# Altium schematic sheet INTEGRATION_SHEET.SchDoc
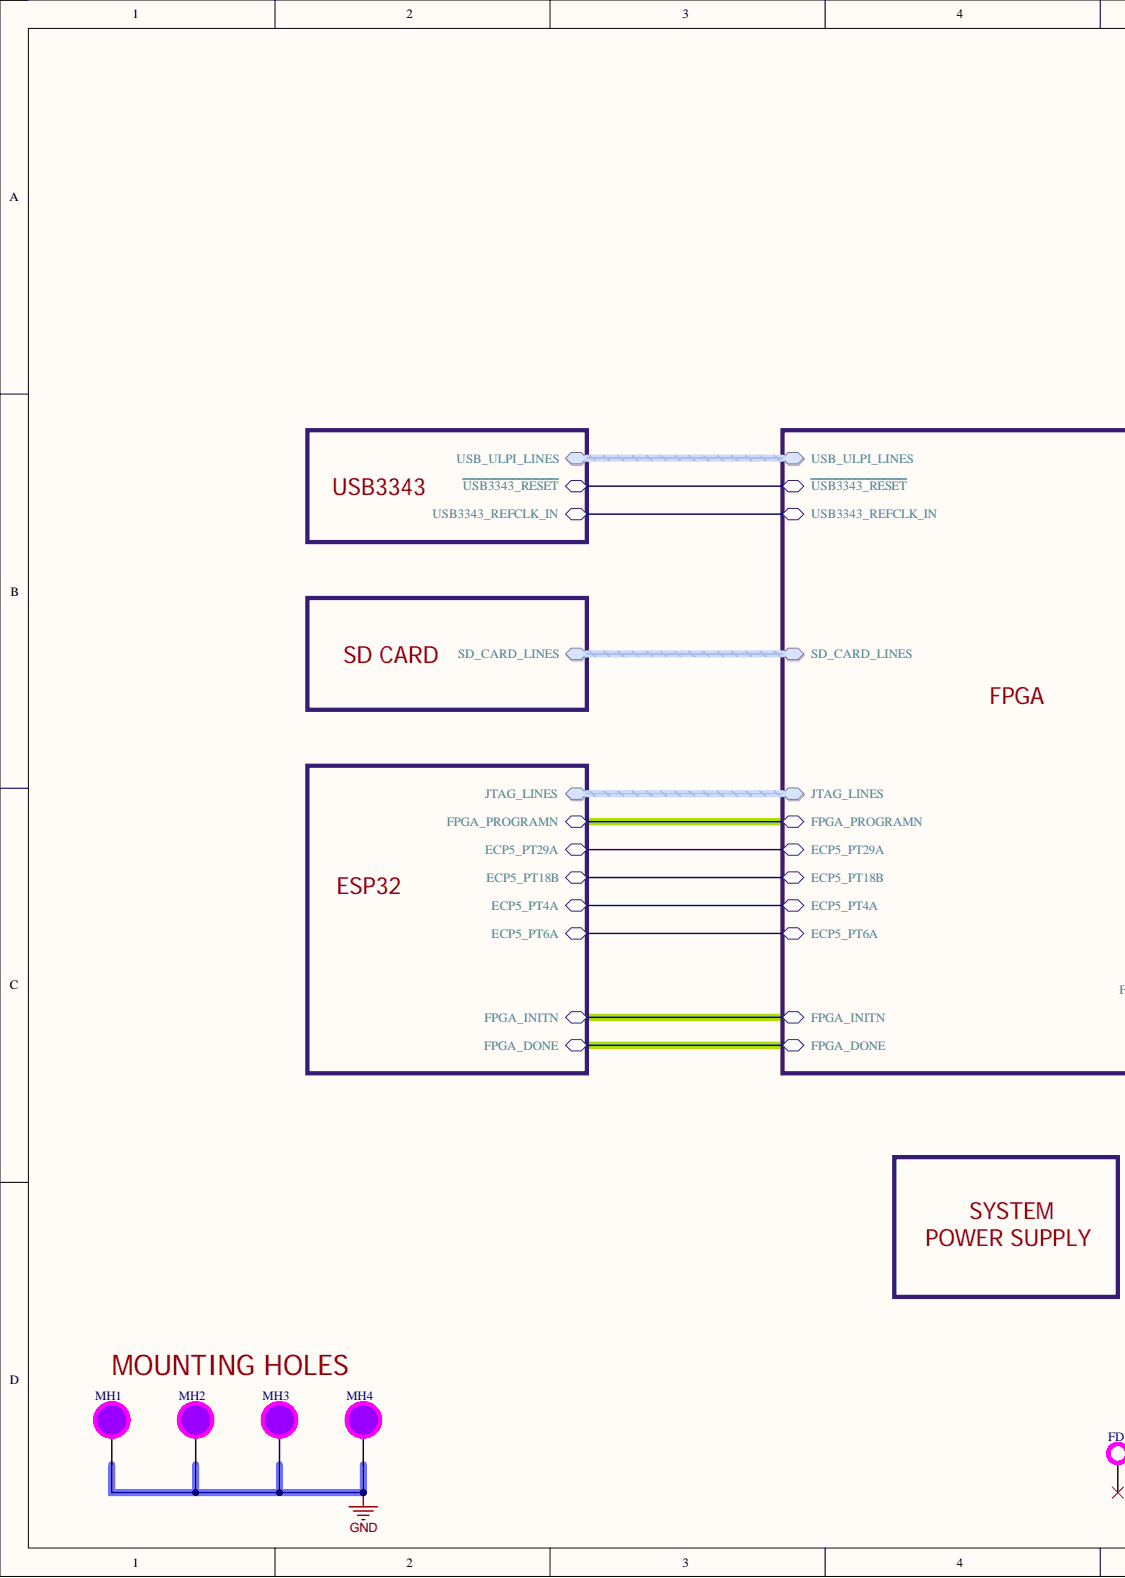
[diagram p0: INTEGRATION_SHEET.SchDoc, left]
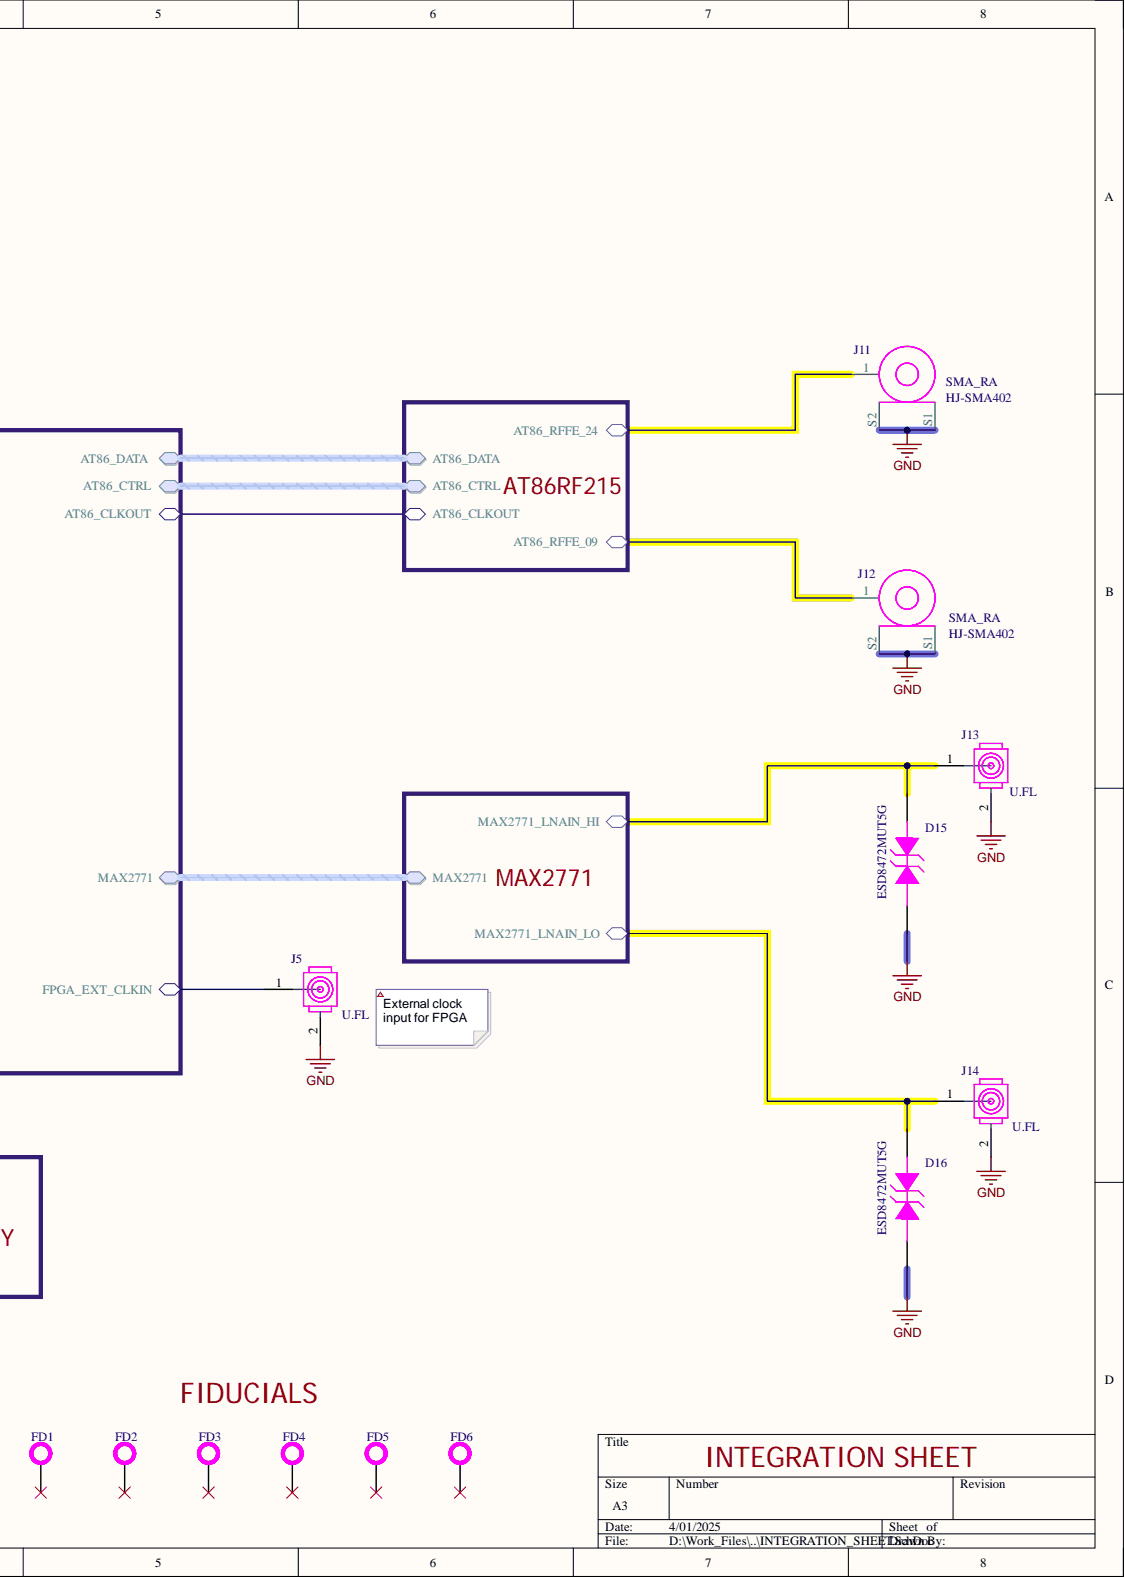
[diagram p1: INTEGRATION_SHEET.SchDoc, right]

## Parts
D15  ESD8472MUT5G  [DIODFN62X32X33-2N]
D16  ESD8472MUT5G  [DIODFN62X32X33-2N]
FD1  FIDUCIAL  [FIDUCIALS]  (no BOM)
FD2  FIDUCIAL  [FIDUCIALS]  (no BOM)
FD3  FIDUCIAL  [FIDUCIALS]  (no BOM)
FD4  FIDUCIAL  [FIDUCIALS]  (no BOM)
FD5  FIDUCIAL  [FIDUCIALS]  (no BOM)
FD6  FIDUCIAL  [FIDUCIALS]  (no BOM)
J5  U.FL  [U.FL Connector]
J11  SMA_RA  [HJ-SMA402_FOOTPRINT]
J12  SMA_RA  [HJ-SMA402_FOOTPRINT]
J13  U.FL  [U.FL Connector]
J14  U.FL  [U.FL Connector]
MH1  Mounting Hole  [Mounting_Hole_3.3mm]  (value not drawn)  (no BOM)
MH2  Mounting Hole  [Mounting_Hole_3.3mm]  (value not drawn)  (no BOM)
MH3  Mounting Hole  [Mounting_Hole_3.3mm]  (value not drawn)  (no BOM)
MH4  Mounting Hole  [Mounting_Hole_3.3mm]  (value not drawn)  (no BOM)

## Nets
GND: D15.1 D16.1 J5.2 J11.S1 J11.S2 J12.S1 J12.S2 J13.2 J14.2 MH1.1 MH2.1 MH3.1 MH4.1
NetD15_2: D15.2 J13.1
NetD16_2: D16.2 J14.1
NetFD1_1: FD1.1
NetFD2_1: FD2.1
NetFD3_1: FD3.1
NetFD4_1: FD4.1
NetFD5_1: FD5.1
NetFD6_1: FD6.1
NetJ5_1: J5.1
NetJ11_1: J11.1
NetJ12_1: J12.1
N00001:
N00002:
N00003:
N00004:
N00005:
N00006:
N00007:
N00008:
N00009:
N00010:
N00011:
N00012:
N00013:
N00014:
N00015:
N00016:
N00017:
N00018:
N00019:
N00020:
N00021:
N00022:
N00023:
N00024:
N00025:
N00026:
N00027:
N00028:
N00029:
N00030:
N00031:
N00032:
N00033:
N00034:
N00035:
N00036:
N00037:
N00038:
N00039:
N00040:
N00041:
N00042:
N00043:
N00044:
N00045:
N00046:
N00047:
N00048:
N00049:
N00050:
N00051:
N00052:
N00053:
N00054:
N00055:
N00056:
N00057:
N00058:
N00059:

## Library data of the parts
D15 D16 = ESD8472MUT5G_LCSC: ESD Suppressor TVS Bi-Dir 5.3V 2-Pin X3-DFN T/R; Manufacturer: onsemi; Component Kind: Standard; ComponentLink1Description: SupplierURL; ComponentLink1URL: https://www.lcsc.com/product-detail/span-style-background-color-ff0-ESD-span-Protection-Devices_onsemi-ESD8472MUT5G_C133346.html; DigikeyPartNumber: ESD8472MUT5GOSCT-ND; Library Name: Diodes.IntLib; ManufacturerPartNumber: ESD8472MUT5G; Price: 0.0709; Supplier: LCSC; SupplierPartNumber: C133346
FD1-FD6 = FIDUCIALS: ClassName: FID; Description: Fiducials; Library Name: connectors.IntLib
J5 J13 J14 = U.FL-R-SMT-1(80): 1 Inner needle IPEX Board Edge 1.25mm -40℃~+90℃ 6GHz 50Ω 2mm SMD RF Connectors / Coaxial Connectors ROHS; Manufacturer: HRS; MaxTemp: +90℃; MinTemp: -40℃; Component Kind: Standard; ComponentLink1Description: SupplierURL; ComponentLink1URL: https://www.lcsc.com/product-detail/RF-Connectors-Coaxial-Connectors_HRS-Hirose-U-FL-R-SMT-1-80_C88374.html; DigikeyPartNumber: 26-U.FL-R-SMT-1(80)CT-ND; Library Name: connectors.IntLib; ManufacturerPartNumber: U.FL-R-SMT-1(80); Price: 0.0802; Supplier: LCSC; SupplierPartNumber: C88374
J11 J12 = HJ-SMA402: 1 Inner bore SMA Board Edge Bend 6GHz -55℃~+155℃ 50Ω Plugin RF Connectors / Coaxial Connectors ROHS; Manufacturer: HJ Tech; Component Kind: Standard; ComponentLink1Description: SupplierURL; ComponentLink1URL: https://www.lcsc.com/product-detail/RF-Connectors-Coaxial-Connectors_HJ-Tech-HJ-SMA402_C1509207.html; Library Name: connectors.IntLib; ManufacturerPartNumber: HJ-SMA402; Price: 0.7361; Supplier: LCSC; SupplierPartNumber: C1509207
MH1-MH4 = 3MM_MOUNTING_HOLE: 3.3mm Mounting Hole; Library Name: connectors.IntLib
